# Altium schematic sheet Control.SchDoc
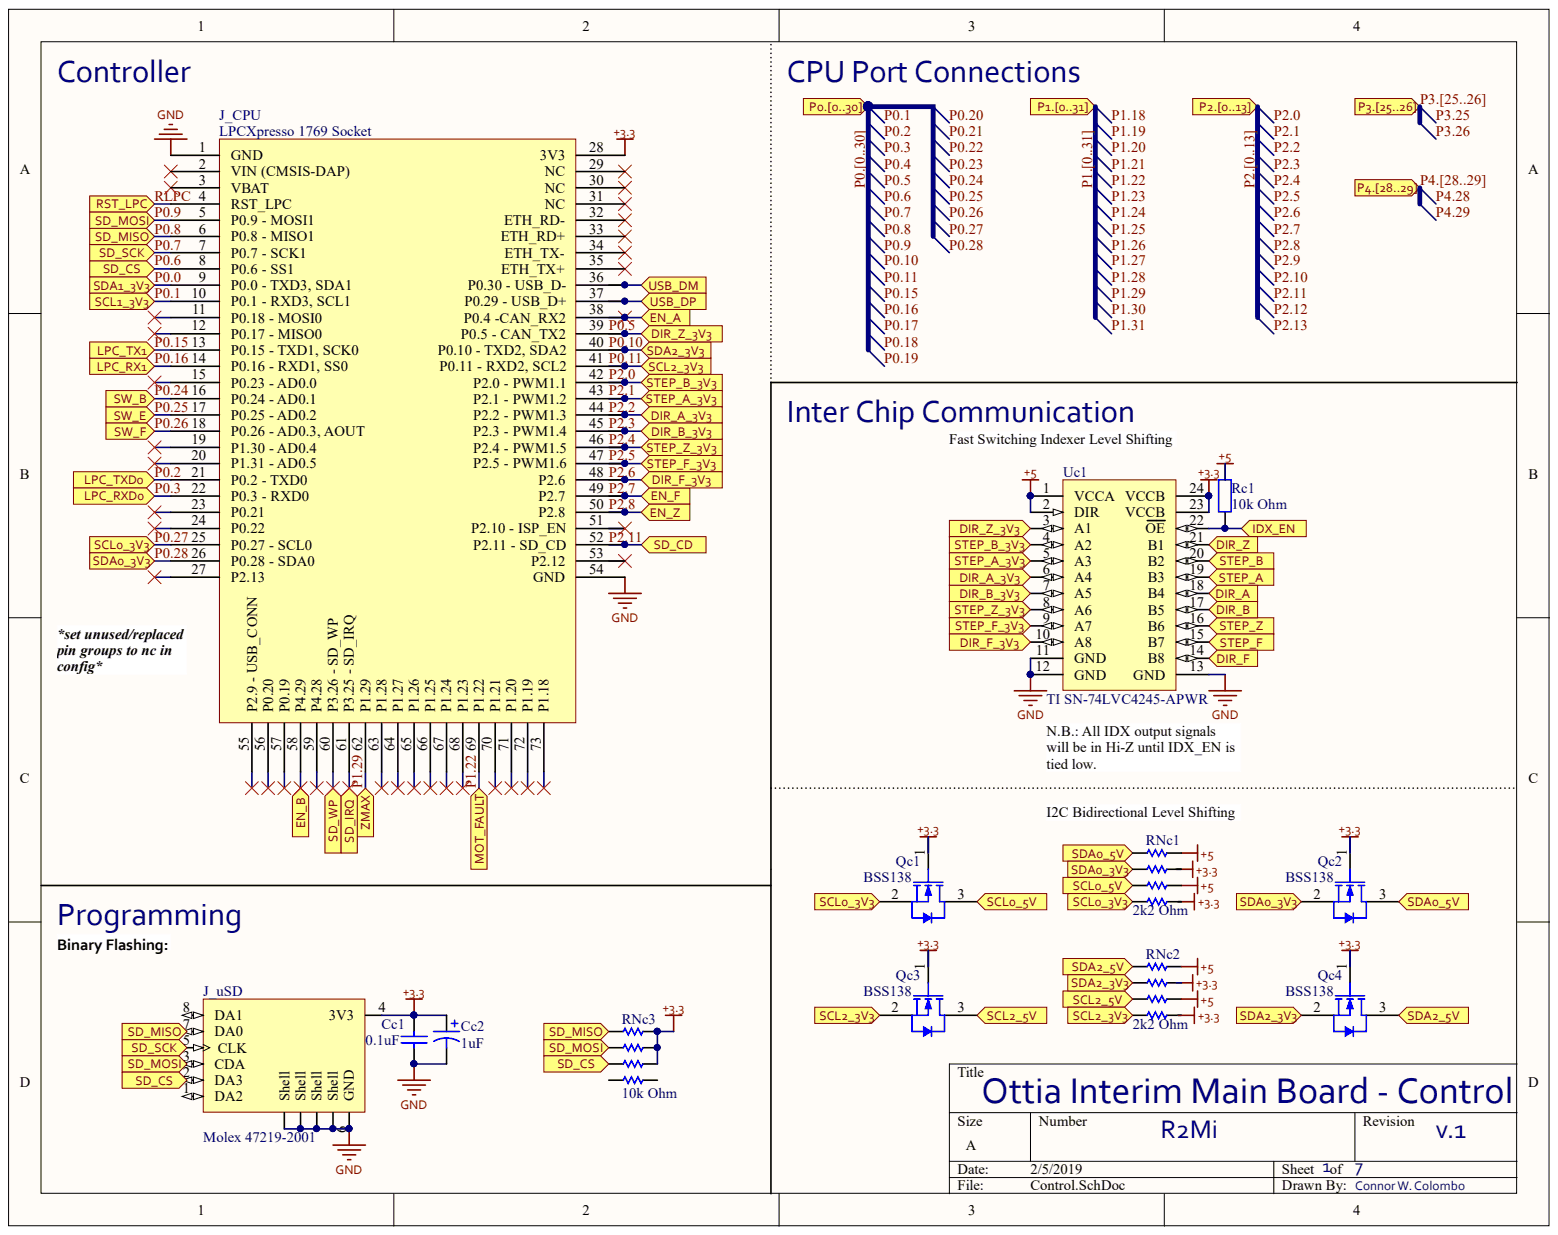
[diagram p0: Control.SchDoc]

## Parts
Cc1  0.1uF  [CAPC1608X90X35ML15T15]
Cc2  1uF  [PCB-rufcymyklsjsmb7phwvw-1]
J_CPU  LPCXpresso 1769 Socket  [PCB-dwl7k3iudk6fyzyo2hyj-1]
J_uSD  Molex 47219-2001  Manufacturer: Molex  [Molex 47219-2001]
Qc1  BSS138  [FAIR-SOT23-3_V]
Qc2  BSS138  [FAIR-SOT23-3_V]
Qc3  BSS138  [FAIR-SOT23-3_V]
Qc4  BSS138  [FAIR-SOT23-3_V]
Rc1  10k Ohm  [PCB-55aunbi2drca6iadvin9-1]
RNc1  2k2 Ohm  [PCB-xr98afuue4hupam51itr-1]
RNc2  2k2 Ohm  [PCB-xr98afuue4hupam51itr-1]
RNc3  10k Ohm  [PCB-jvpnorgmdmak109y2eet-1]
Uc1  TI SN-74LVC4245-APWR  [PCB-19361417-1]

## Ports
DIR_A, DIR_A_3V3, DIR_B, DIR_B_3V3, DIR_F, DIR_F_3V3, DIR_Z, DIR_Z_3V3, EN_A, EN_B, EN_F, EN_Z, IDX_EN, LPC_RX1, LPC_RXD0, LPC_TX1, LPC_TXD0, MOT_FAULT, P0.0, P0.1, P0.2, P0.3, P0.4, P0.5, P0.6, P0.7, P0.8, P0.9, P0.10, P0.11, P0.12, P0.13, P0.14, P0.15, P0.16, P0.17, P0.18, P0.19, P0.20, P0.21, P0.22, P0.23, P0.24, P0.25, P0.26, P0.27, P0.28, P0.29, P0.30, P0.[0..30], P1.0, P1.1, P1.2, P1.3, P1.4, P1.5, P1.6, P1.7, P1.8, P1.9, P1.10, P1.11, P1.12, P1.13, P1.14, P1.15, P1.16, P1.17, P1.18, P1.19, P1.20, P1.21, P1.22, P1.23, P1.24, P1.25, P1.26, P1.27, P1.28, P1.29, P1.30, P1.31, P1.[0..31], P2.0, P2.1, P2.2, P2.3, P2.4, P2.5, P2.6, P2.7, P2.8, P2.9, P2.10, P2.11, P2.12, P2.13, P2.[0..13], P3.25, P3.26, P3.[25..26], P4.28, P4.29, P4.[28..29], RST_LPC, SCL0_3V3, SCL0_5V, SCL1_3V3, SCL2_3V3, SCL2_5V, SD_CD, SD_CS, SD_IRQ, SD_MISO, SD_MOSI, SD_SCK, SD_WP, SDA0_3V3, SDA0_5V, SDA1_3V3, SDA2_3V3, SDA2_5V, STEP_A, STEP_A_3V3, STEP_B, STEP_B_3V3, STEP_F, STEP_F_3V3, STEP_Z, STEP_Z_3V3, SW_B, SW_E, SW_F, USB_DM, USB_DP, ZMAX

## Nets
+3.3: Cc1.2 Cc2.1 J_CPU.28(3V3) J_uSD.4(3V3) Qc1.1 Qc2.1 Qc3.1 Qc4.1 RNc1.5 RNc1.7 RNc2.5 RNc2.7 RNc3.6 RNc3.7 RNc3.8 Uc1.23(VCCB) Uc1.24(VCCB)
+5: Rc1.1 RNc1.6 RNc1.8 RNc2.6 RNc2.8 Uc1.1(VCCA) Uc1.2(DIR)
GND: Cc1.1 Cc2.2 J_CPU.1(GND) J_CPU.54(GND) J_uSD.6(GND) J_uSD.MT1(Shell) J_uSD.MT2(Shell) J_uSD.MT3(Shell) J_uSD.MT4(Shell) Uc1.11(GND) Uc1.12(GND) Uc1.13(GND)
DIR_A: Uc1.18(B4) ; port DIR_A
DIR_B: Uc1.17(B5) ; port DIR_B
DIR_F: Uc1.14(B8) ; port DIR_F
DIR_Z: Uc1.21(B1) ; port DIR_Z
EN_A: J_CPU.38(P0.4_-CAN_RX2) ; port EN_A
EN_B: J_CPU.58(P4.29) ; port EN_B
IDX_EN: Rc1.2 Uc1.22(OE) ; port IDX_EN
P0.0: J_CPU.9(P0.0_-_TXD3,_SDA1) ; port P0.[0..30], port SDA1_3V3  [also labelled P0.]
P0.1: J_CPU.10(P0.1_-_RXD3,_SCL1) ; port P0.[0..30], port SCL1_3V3  [also labelled P0.]
P0.2: J_CPU.21(P0.2_-_TXD0) ; port LPC_TXD0, port P0.[0..30]  [also labelled P0.]
P0.3: J_CPU.22(P0.3_-_RXD0) ; port LPC_RXD0, port P0.[0..30]  [also labelled P0.]
P0.5: J_CPU.39(P0.5_-_CAN_TX2) Uc1.3(A1) ; port DIR_Z_3V3, port P0.[0..30]  [also labelled P0.]
P0.6: J_CPU.8(P0.6_-_SS1) J_uSD.2(DA3) RNc3.3 ; port P0.[0..30], port SD_CS  [also labelled P0.]
P0.7: J_CPU.7(P0.7_-_SCK1) J_uSD.5(CLK) ; port P0.[0..30], port SD_SCK  [also labelled P0.]
P0.8: J_CPU.6(P0.8_-_MISO1) J_uSD.7(DA0) RNc3.1 ; port P0.[0..30], port SD_MISO  [also labelled P0.]
P0.9: J_CPU.5(P0.9_-_MOSI1) J_uSD.3(CDA) RNc3.2 ; port P0.[0..30], port SD_MOSI  [also labelled P0.]
P0.10: J_CPU.40(P0.10_-_TXD2,_SDA2) Qc4.2 RNc2.2 ; port P0.[0..30], port SDA2_3V3  [also labelled P0.]
P0.11: J_CPU.41(P0.11_-_RXD2,_SCL2) Qc3.2 RNc2.4 ; port P0.[0..30], port SCL2_3V3  [also labelled P0.]
P0.15: J_CPU.13(P0.15_-_TXD1,_SCK0) ; port LPC_TX1, port P0.[0..30]  [also labelled P0.]
P0.16: J_CPU.14(P0.16_-_RXD1,_SS0) ; port LPC_RX1, port P0.[0..30]  [also labelled P0.]
P0.24: J_CPU.16(P0.24_-_AD0.1) ; port P0.[0..30], port SW_B  [also labelled P0.]
P0.25: J_CPU.17(P0.25_-_AD0.2) ; port P0.[0..30], port SW_E  [also labelled P0.]
P0.26: J_CPU.18(P0.26_-_AD0.3,_AOUT) ; port P0.[0..30], port SW_F  [also labelled P0.]
P0.27: J_CPU.25(P0.27_-_SCL0) Qc1.2 RNc1.4 ; port P0.[0..30], port SCL0_3V3  [also labelled P0.]
P0.28: J_CPU.26(P0.28_-_SDA0) Qc2.2 RNc1.2 ; port P0.[0..30], port SDA0_3V3  [also labelled P0.]
P1.22: J_CPU.69(P1.22) ; port MOT_FAULT, port P1.[0..31]  [also labelled P1.]
P1.29: J_CPU.62(P1.29) ; port P1.[0..31], port ZMAX  [also labelled P1.]
P2.0: J_CPU.42(P2.0_-_PWM1.1) Uc1.4(A2) ; port P2.[0..13], port STEP_B_3V3  [also labelled P2.]
P2.1: J_CPU.43(P2.1_-_PWM1.2) Uc1.5(A3) ; port P2.[0..13], port STEP_A_3V3  [also labelled P2.]
P2.2: J_CPU.44(P2.2_-_PWM1.3) Uc1.6(A4) ; port DIR_A_3V3, port P2.[0..13]  [also labelled P2.]
P2.3: J_CPU.45(P2.3_-_PWM1.4) Uc1.7(A5) ; port DIR_B_3V3, port P2.[0..13]  [also labelled P2.]
P2.4: J_CPU.46(P2.4_-_PWM1.5) Uc1.8(A6) ; port P2.[0..13], port STEP_Z_3V3  [also labelled P2.]
P2.5: J_CPU.47(P2.5_-_PWM1.6) Uc1.9(A7) ; port P2.[0..13], port STEP_F_3V3  [also labelled P2.]
P2.6: J_CPU.48(P2.6) Uc1.10(A8) ; port DIR_F_3V3, port P2.[0..13]  [also labelled P2.]
P2.7: J_CPU.49(P2.7) ; port EN_F, port P2.[0..13]  [also labelled P2.]
P2.8: J_CPU.50(P2.8) ; port EN_Z, port P2.[0..13]  [also labelled P2.]
P2.11: J_CPU.52(P2.11_-_SD_CD) ; port P2.[0..13], port SD_CD  [also labelled P2.]
RLPC: J_CPU.4(RST_LPC) ; port RST_LPC
SCL0_5V: Qc1.3 RNc1.3 ; port SCL0_5V
SCL2_5V: Qc3.3 RNc2.3 ; port SCL2_5V
SD_IRQ: J_CPU.61(P3.25_-_SD_IRQ) ; port SD_IRQ
SD_WP: J_CPU.60(P3.26_-_SD_WP) ; port SD_WP
SDA0_5V: Qc2.3 RNc1.1 ; port SDA0_5V
SDA2_5V: Qc4.3 RNc2.1 ; port SDA2_5V
STEP_A: Uc1.19(B3) ; port STEP_A
STEP_B: Uc1.20(B2) ; port STEP_B
STEP_F: Uc1.15(B7) ; port STEP_F
STEP_Z: Uc1.16(B6) ; port STEP_Z
USB_DM: J_CPU.36(P0.30_-_USB_D-) ; port USB_DM
USB_DP: J_CPU.37(P0.29_-_USB_D+) ; port USB_DP
P0.4: ; port P0.[0..30]  [also labelled P0.]
P0.12: ; port P0.[0..30]  [also labelled P0.]
P0.13: ; port P0.[0..30]  [also labelled P0.]
P0.14: ; port P0.[0..30]  [also labelled P0.]
P0.17: ; port P0.[0..30]  [also labelled P0.]
P0.18: ; port P0.[0..30]  [also labelled P0.]
P0.19: ; port P0.[0..30]  [also labelled P0.]
P0.20: ; port P0.[0..30]  [also labelled P0.]
P0.21: ; port P0.[0..30]  [also labelled P0.]
P0.22: ; port P0.[0..30]  [also labelled P0.]
P0.23: ; port P0.[0..30]  [also labelled P0.]
P0.29: ; port P0.[0..30]  [also labelled P0.]
P0.30: ; port P0.[0..30]  [also labelled P0.]
P1.0: ; port P1.[0..31]  [also labelled P1.]
P1.1: ; port P1.[0..31]  [also labelled P1.]
P1.2: ; port P1.[0..31]  [also labelled P1.]
P1.3: ; port P1.[0..31]  [also labelled P1.]
P1.4: ; port P1.[0..31]  [also labelled P1.]
P1.5: ; port P1.[0..31]  [also labelled P1.]
P1.6: ; port P1.[0..31]  [also labelled P1.]
P1.7: ; port P1.[0..31]  [also labelled P1.]
P1.8: ; port P1.[0..31]  [also labelled P1.]
P1.9: ; port P1.[0..31]  [also labelled P1.]
P1.10: ; port P1.[0..31]  [also labelled P1.]
P1.11: ; port P1.[0..31]  [also labelled P1.]
P1.12: ; port P1.[0..31]  [also labelled P1.]
P1.13: ; port P1.[0..31]  [also labelled P1.]
P1.14: ; port P1.[0..31]  [also labelled P1.]
P1.15: ; port P1.[0..31]  [also labelled P1.]
P1.16: ; port P1.[0..31]  [also labelled P1.]
P1.17: ; port P1.[0..31]  [also labelled P1.]
P1.18: ; port P1.[0..31]  [also labelled P1.]
P1.19: ; port P1.[0..31]  [also labelled P1.]
P1.20: ; port P1.[0..31]  [also labelled P1.]
P1.21: ; port P1.[0..31]  [also labelled P1.]
P1.23: ; port P1.[0..31]  [also labelled P1.]
P1.24: ; port P1.[0..31]  [also labelled P1.]
P1.25: ; port P1.[0..31]  [also labelled P1.]
P1.26: ; port P1.[0..31]  [also labelled P1.]
P1.27: ; port P1.[0..31]  [also labelled P1.]
P1.28: ; port P1.[0..31]  [also labelled P1.]
P1.30: ; port P1.[0..31]  [also labelled P1.]
P1.31: ; port P1.[0..31]  [also labelled P1.]
P2.9: ; port P2.[0..13]  [also labelled P2.]
P2.10: ; port P2.[0..13]  [also labelled P2.]
P2.12: ; port P2.[0..13]  [also labelled P2.]
P2.13: ; port P2.[0..13]  [also labelled P2.]
P3.25: ; port P3.[25..26]  [also labelled P3.]
P3.26: ; port P3.[25..26]  [also labelled P3.]
P4.28: ; port P4.[28..29]  [also labelled P4.]
P4.29: ; port P4.[28..29]  [also labelled P4.]

Not connected: J_CPU 2(VIN_(CMSIS-DAP)) 3(VBAT) 11(P0.18_-_MOSI0) 12(P0.17_-_MISO0) 15(P0.23_-_AD0.0) 19(P1.30_-_AD0.4) 20(P1.31_-_AD0.5) 23(P0.21) 24(P0.22) 27(P2.13) 29(NC) 30(NC) 31(NC) 32(ETH_RD-) 33(ETH_RD+) 34(ETH_TX-) 35(ETH_TX+) 51(P2.10_-_ISP_EN) 53(P2.12) 55(P2.9_-_USB_CONN) 56(P0.20) 57(P0.19) 59(P4.28) 63(P1.28) 64(P1.27) 65(P1.26) 66(P1.25) 67(P1.24) 68(P1.23) 70(P1.21) 71(P1.20) 72(P1.19) 73(P1.18); J_uSD 1(DA2) 8(DA1); RNc3 4 5

## Library data of the parts
Cc1 = CMP-15328962-11: 0603 0.1uF Capacitor; Capacitance: 1e-07 F; Capacitance Tolerance: ±10%; Dielectric Characteristic: X7R; Dielectric Material: Ceramic Multilayer; Insulation Resistance: 1e+11 Ω; Lead-Free Status: Lead Free; Voltage Rating (DC): 16.0 V; Case/Package: 0603; Component Kind: Standard; Library Name: CommunityVault; Mounting Style: Surface Mount; Number of Pins: 2; OctopartID: 0cd5c6920958d312; Octopart Url: https://octopart.com/0603yc104kat2a-avx+interconnect+%2F+elco-55386347; REACH SVHC Compliance: No SVHC; RoHS: Compliant; Simulation: SIM-9frhrb8yuhxfmab8hy02-1; Size-Height: 0.00086 m; Size-Length: 0.0016002 m; Size-Width: 0.0008128 m
Cc2 = CMP-adcf64c4c6c97208-1: 1uF Solid MnO2 Tantalum Electrolytic Capacitor; 35 V dc +/-10%; T491 Series; Manufacturer Part Number: T491B105K035AT; Capacitance: 1.00 µF; Capacitance Tolerance: ±10%; Case/Package (SI): 3528-21; Equivalent Series Resistance (ESR): 5.00 ?; Lead-Free Status: Lead Free; Voltage Rating (DC): 35.0 V; Case/Package: 3528-21; Component Kind: Standard; Dielectric Material: Tantalum; Library Name: FavoritesLibrary; Mounting Style: Surface Mount; Number of Pins: 2; OctopartID: adcf64c4c6c97208; Octopart URL: https://octopart.com/t491b105k035at-kemet-85600; Operating Temperature: -55.0 °C to 125 °C; Packaging: Tape & Reel (TR), Cut Tape (CT); REACH SVHC Compliance: No SVHC; RoHS: Compliant; Size-Height: 1.90 mm; Size-Length: 3.50 mm; Size-Width: 2.80 mm
J_CPU = CMP-4f1j3nb7r6ngphmd7n3t-7: NXP LPCXPresso Breakout; Component Kind: Standard; CustomComponentID: 4f1j3nb7r6ngphmd7n3t; Library Name: CommunityVault
J_uSD = 47219-2001: Conn Micro SD Card SKT 8 POS 1.1mm Solder RA SMD Embossed T/R 0.5A/Contact; Component Kind: Standard; Library Name: Octopart library; Lifecycle Status: Active; OctopartID: 95805dba04df4a47; Octopart URL: http://octopart.com/0472192001-molex-19969081
Qc1-Qc4 = BSS138: N-Channel Logic Level Enhancement Mode Field Effect Transistor, 220 mA, 50 V, -55 to 150 degC, 3-Pin SOT-23, RoHS, Tape and Reel; Breakdown Voltage (Drain to Source): 50.0 V; Breakdown Voltage [Gate to Source]: -20.0 V to 20.0 V; Continuous Drain Current (Ids): 220 mA; Drain to Source Resistance (on) (Rds): 3.50 Ω; Gate Charge: 2.40 nC; Input Capacitance: 27.0 pF; Lead-Free Status: Lead Free; Power Dissipation: 360 mW; Case/Package: SOT-23; Component Kind: Standard; Current Rating: 220 mA; DatasheetVersion: Rev. C, 10/2005; Drain to Source Voltage (Vds): 50.0 V; Library Name: Octopart library; Lifecycle Status: Active; Manufacturer Part Number: BSS138; Mounting Style: Surface Mount; Number of Pins: 3; OctopartID: 2868e1aa5c2af7af; Octopart Url: http://octopart.com/bss138-fairchild+semiconductor-13127972; PackageDescription: 3-Pin Small Outline Transistor, Body 2.92 x 1.325 mm, Pitch 0.95 mm; PackageReference: SOT23-3; Packaging: Cut Tape (CT), Tape & Reel (TR); Polarity: N-Channel; REACH SVHC Compliance: No SVHC; RoHS: Compliant; Seller Landing  Url: https://octopart-clicks.com/click/track?country=US&ak=8157f166&sig=0b39d47&sid=1106&ppid=13127972&vpid=21094599&ct=offers; Voltage Rating (DC): 50.0 V
Rc1 = CMP-494d8056daa7968a-16: Res Thick Film 1.6 x 0.8 mm 10K Ohm 5% 0.1W(1/10W) 100ppm/ C Molded SMD Paper T/R; Component Kind: Standard; Library Name: CommunityVault
RNc1 RNc2 = CMP-3fa9f4fca32de06e-1: Res Thick Film Array 2.2K Ohm 5% ±200ppm/C ISOL Epoxy 8-Pin 1206(4 X 0603) Concave SMD T/R; Manufacturer Part Number: TC164-JR-072K2L; Number of Circuits: 4; Number of Contacts: 8; Voltage Rating (AC): 50.0 V; Component Kind: Standard; Library Name: CommunityVault; Mounting Style: Surface Mount; REACH SVHC Compliance: No SVHC; RoHS: Compliant; Size-Length: 3.20 mm; Size-Width: 1.60 mm
RNc3 = YC164-JR-0710KL: Res Thick Film Array 10K Ohm 5% ???}200ppm/??? ISOL Molded 8-Pin 1206(4 X 0603) Convex SMD Paper T/R; Voltage Rating (AC): 50.0 V; Component Kind: Standard; Library Name: Octopart library; Manufacturer Part Number: YC164-JR-0710KL; Mounting Style: Surface Mount; Packaging: Tape & Reel; Resistance Tolerance: ±5%; Voltage Rating (DC): 50.0 V
Uc1 = SN74LVC4245APWR: IC BUS TRANSCEIVER 8BIT 24TSSOP; Lead-Free Status: Lead Free; Number of Bits: 8; Output Current Drive: -0.001 A; Propagation Delay Max (tpd): 3.7e-09 s; Supply Voltage (DC): 3.0 V; Case/Package: TSSOP; Component Kind: Standard; Library Name: Octopart library; Lifecycle Status: Active; Manufacturer Part Number: SN74LVC4245APWR; Mounting Style: Surface Mount; Number of Circuits: 8; Number of Outputs: 8; Number of Pins: 24; OctopartID: 59fe90f39e7a8639; Octopart Url: https://octopart.com/sn74lvc4245apwr-texas+instruments-516834; REACH SVHC Compliance: No SVHC; RoHS: Compliant
